# Altium schematic sheet Top Level.SchDoc("Top Level")
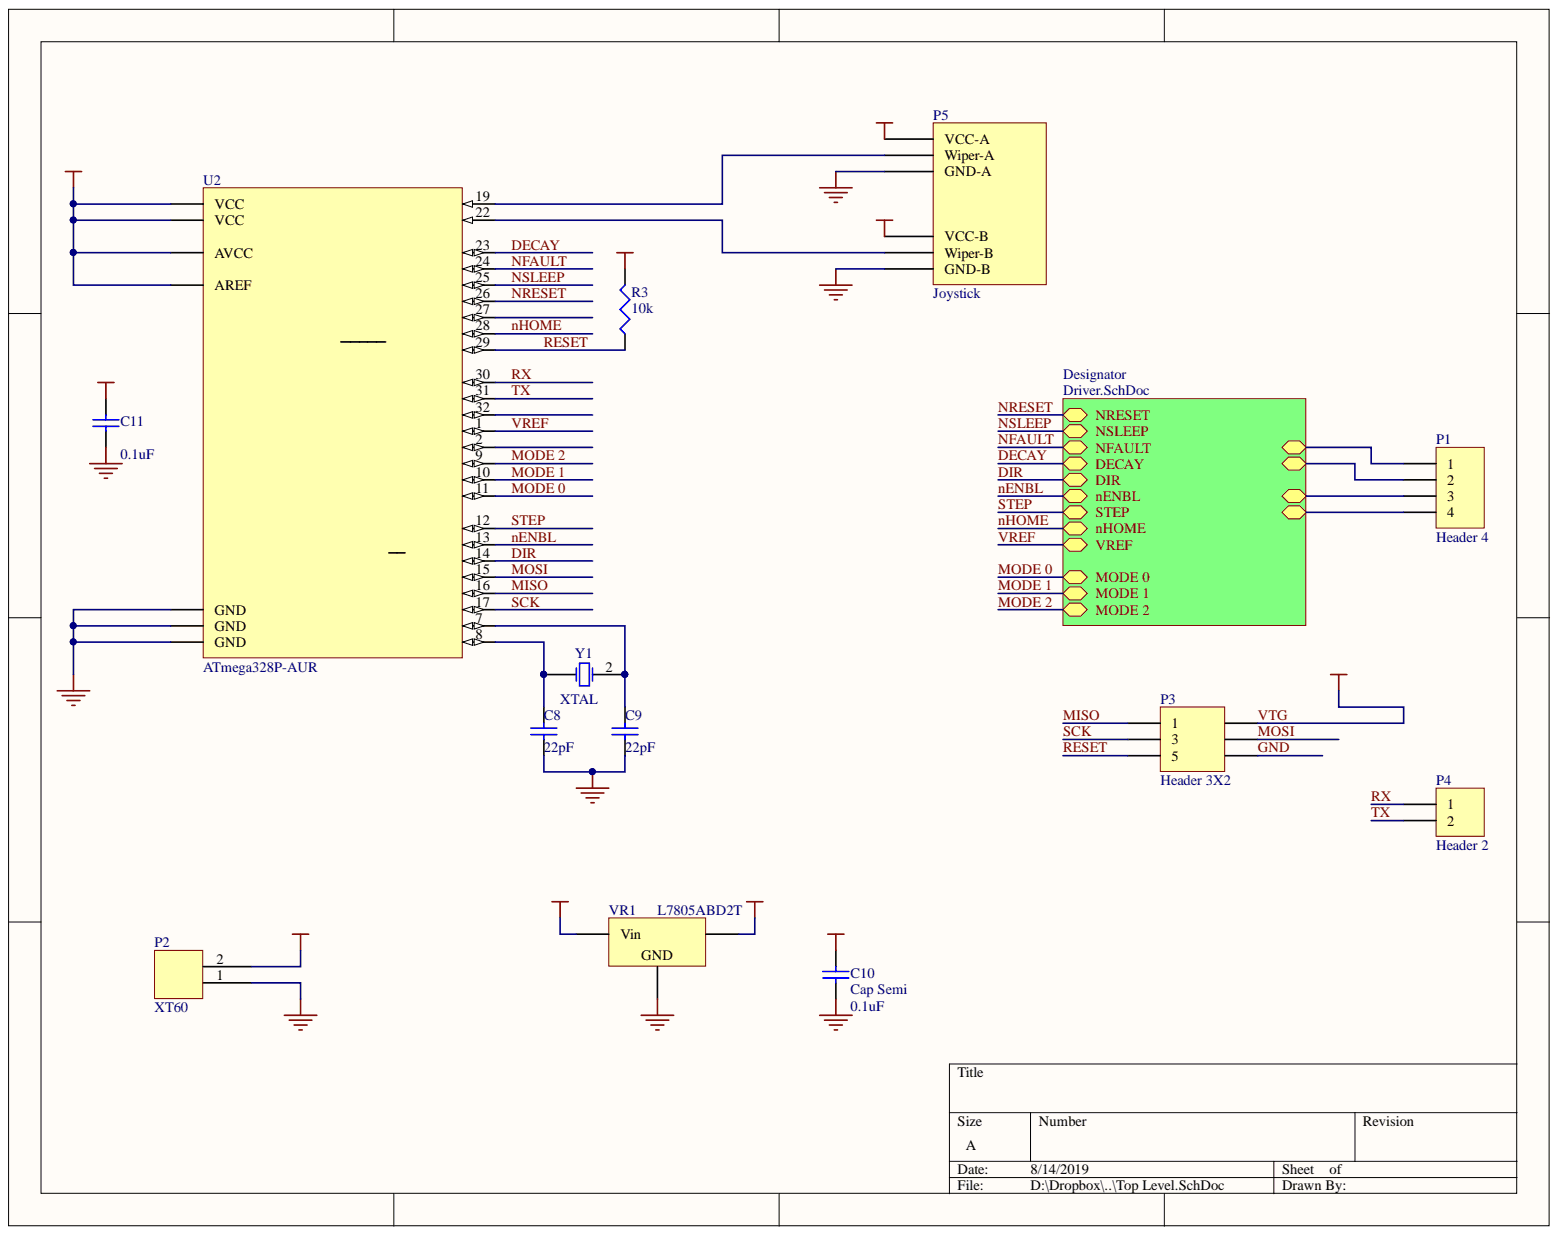
[diagram p0: Top Level.SchDoc]

## Parts
C8  value 22pF  [C0805]  (hidden comment: Cap)
C9  value 22pF  [C0805]  (hidden comment: Cap)
C10  Cap Semi  value 0.1uF  [C1206]
C11  value 0.1uF  [C1206]  (hidden comment: Cap Semi)
P1  Header 4  [HDR1X4]
P2  XT60  [xt60]
P3  Header 3X2  [HDR2X3]
P4  Header 2  [HDR1X2]
P5  Joystick  [P2785 - Joystick 2 axis]
R3  value 10k  [J1-0603]  (hidden comment: Res3)
U2  ATmega328P-AUR  [32A_N]
VR1  L7805ABD2T  [D2PAK_N]
Y1  XTAL  [RAD-0.2]

## Nets
VM: P2.2 VR1.1
DECAY: U2.23
DIR: U2.14
GND: C8.1 C9.1 C10.2 C11.2 P2.1 P3.6 P5.3(GND-A) P5.6(GND-B) U2.3(GND) U2.5(GND) U2.21(GND) VR1.2
MISO: P3.1 U2.16
MODE 0: U2.11
MODE 1: U2.10
MODE 2: U2.9
MOSI: P3.4 U2.15
nENBL: U2.13
NFAULT: U2.24
nHOME: U2.28
NRESET: U2.26
NSLEEP: U2.25
RESET: P3.5 R3.1 U2.29
RX: P4.1 U2.30
SCK: P3.3 U2.17
STEP: U2.12
TX: P4.2 U2.31
VREF: U2.1
VTG: C10.1 C11.1 P3.2 P5.1(VCC-A) P5.4(VCC-B) R3.2 U2.4(VCC) U2.6(VCC) U2.18(AVCC) U2.20(AREF) VR1.3
NetC8_2: C8.2 U2.8 Y1.1
NetC9_2: C9.2 U2.7 Y1.2
NetP1_1: P1.1
NetP1_2: P1.2
NetP1_3: P1.3
NetP1_4: P1.4
NetP5_2: P5.2(Wiper-A) U2.19
NetP5_5: P5.5(Wiper-B) U2.22
NetU2_2: U2.2
NetU2_27: U2.27
NetU2_32: U2.32

## Library data of the parts
C8 C9 = Cap: Capacitor; Simulation: CAP; Signal Integrity: Cap; Published: 8-Jun-2000; PackageReference: RAD-0.3; PackageDescription: Radial Cap, Thru-Hole; 2 Leads; 0.3 in Pin Spacing; Library Name: Miscellaneous Devices.IntLib; LatestRevisionNote: Re-released for DXP Platform.; LatestRevisionDate: 17-Jul-2002; Component Kind: Standard; Publisher: Altium Limited
C10 C11 = Cap Semi: Capacitor (Semiconductor SIM Model); Simulation: CAP; Signal Integrity: Cap Semi; Published: 8-Jun-2000; PackageReference: C1206; PackageDescription: Chip Capacitor; Library Name: Miscellaneous Devices.IntLib; LatestRevisionNote: IPC-7351 Footprint Added.; LatestRevisionDate: 29-May-2009; Component Kind: Standard; Publisher: Altium Limited
P1 = Header 4: Header, 4-Pin; Signal Integrity: Connector; Library Name: Miscellaneous Connectors.IntLib; LatestRevisionNote: Re-released for DXP Platform.; LatestRevisionDate: 17-Jul-2002; Component Kind: Standard; Publisher: Altium Limited
P3 = Header 3X2: Header, 3-Pin, Dual row; Signal Integrity: Connector; Library Name: Miscellaneous Connectors.IntLib; LatestRevisionNote: Re-released for DXP Platform.; LatestRevisionDate: 17-Jul-2002; Component Kind: Standard; Publisher: Altium Limited
P4 = Header 2: Header, 2-Pin; Signal Integrity: Connector; Library Name: Miscellaneous Connectors.IntLib; LatestRevisionNote: Re-released for DXP Platform.; LatestRevisionDate: 17-Jul-2002; Component Kind: Standard; Publisher: Altium Limited
P5 = joystick 2 axis: Library Name: Miscellaneous Devices.SchLib; Component Kind: Standard
R3 = Res3: Resistor; Simulation: RESISTOR; Signal Integrity: Res; Published: 8-Jun-2000; PackageReference: J1-0603; PackageDescription: Chip Resistor; Library Name: Miscellaneous Devices.IntLib; LatestRevisionNote: IPC-7351 Footprint Added.; LatestRevisionDate: 29-May-2009; Component Kind: Standard; Publisher: Altium Limited
U2 = ATmega328P-AUR: 8-bit AVR Microcontroller, 32KB Flash, 1KB EEPROM, 2KB SRAM, 32-pin TQFP, Industrial Grade (-40°C to 85°C), Reel; PackageVersion: revC, Oct-2010; PackageReference: 32A; PackageDescription: 32-Lead, 7x7x1.0 mm Body, 0.8mm Lead Pitch, Thin Profile Plastic Quad Flat Package (TQFP); Library Name: Atmel Microcontroller megaAVR.IntLib; DatasheetVersion: revD, May-2011; ComponentLink3URL: http://www.atmel.com/dyn/resources/prod_documents/8271S.pdf; ComponentLink3Description: ATmega48A/48PA/88A/88PA/168A/168PA/328/328P Summary; ComponentLink2URL: http://www.atmel.com/dyn/resources/prod_documents/doc8271.pdf; ComponentLink2Description: ATmega48A/48PA/88A/88PA/168A/168PA/328/328P Datasheet; ComponentLink1URL: http://www.atmel.com/; ComponentLink1Description: Manufacturer URL; Component Kind: Standard; Code_JEDEC: MS-026-ABA
VR1 = Volt Reg: Voltage Regulator; Published: 24-Mar-1999; PackageReference: D2PAK; PackageDescription: 3-Pin Transistor Outline Package 2.54 mm Pitch, 15.1 mm Lead Span; Library Name: Miscellaneous Devices.IntLib; LatestRevisionNote: IPC-7351 Footprint Added.; LatestRevisionDate: 29-May-2009; Component Kind: Standard; Publisher: Altium Limited
Y1 = XTAL: Crystal Oscillator; Simulation: XTAL; Published: 8-Jun-2000; PackageReference: R38; PackageDescription: Crystal, Thru-Hole; 2 Leads; Body 3.1 x 8.2 mm (Dia.xH); Library Name: Miscellaneous Devices.IntLib; LatestRevisionNote: Re-released for DXP Platform.; LatestRevisionDate: 17-Jul-2002; Component Kind: Standard; Publisher: Altium Limited
P2 = XT60: Library Name: XT60.SchLib; Component Kind: Standard
